# Altium schematic sheet servo-connector.SchDoc(servo-connector)
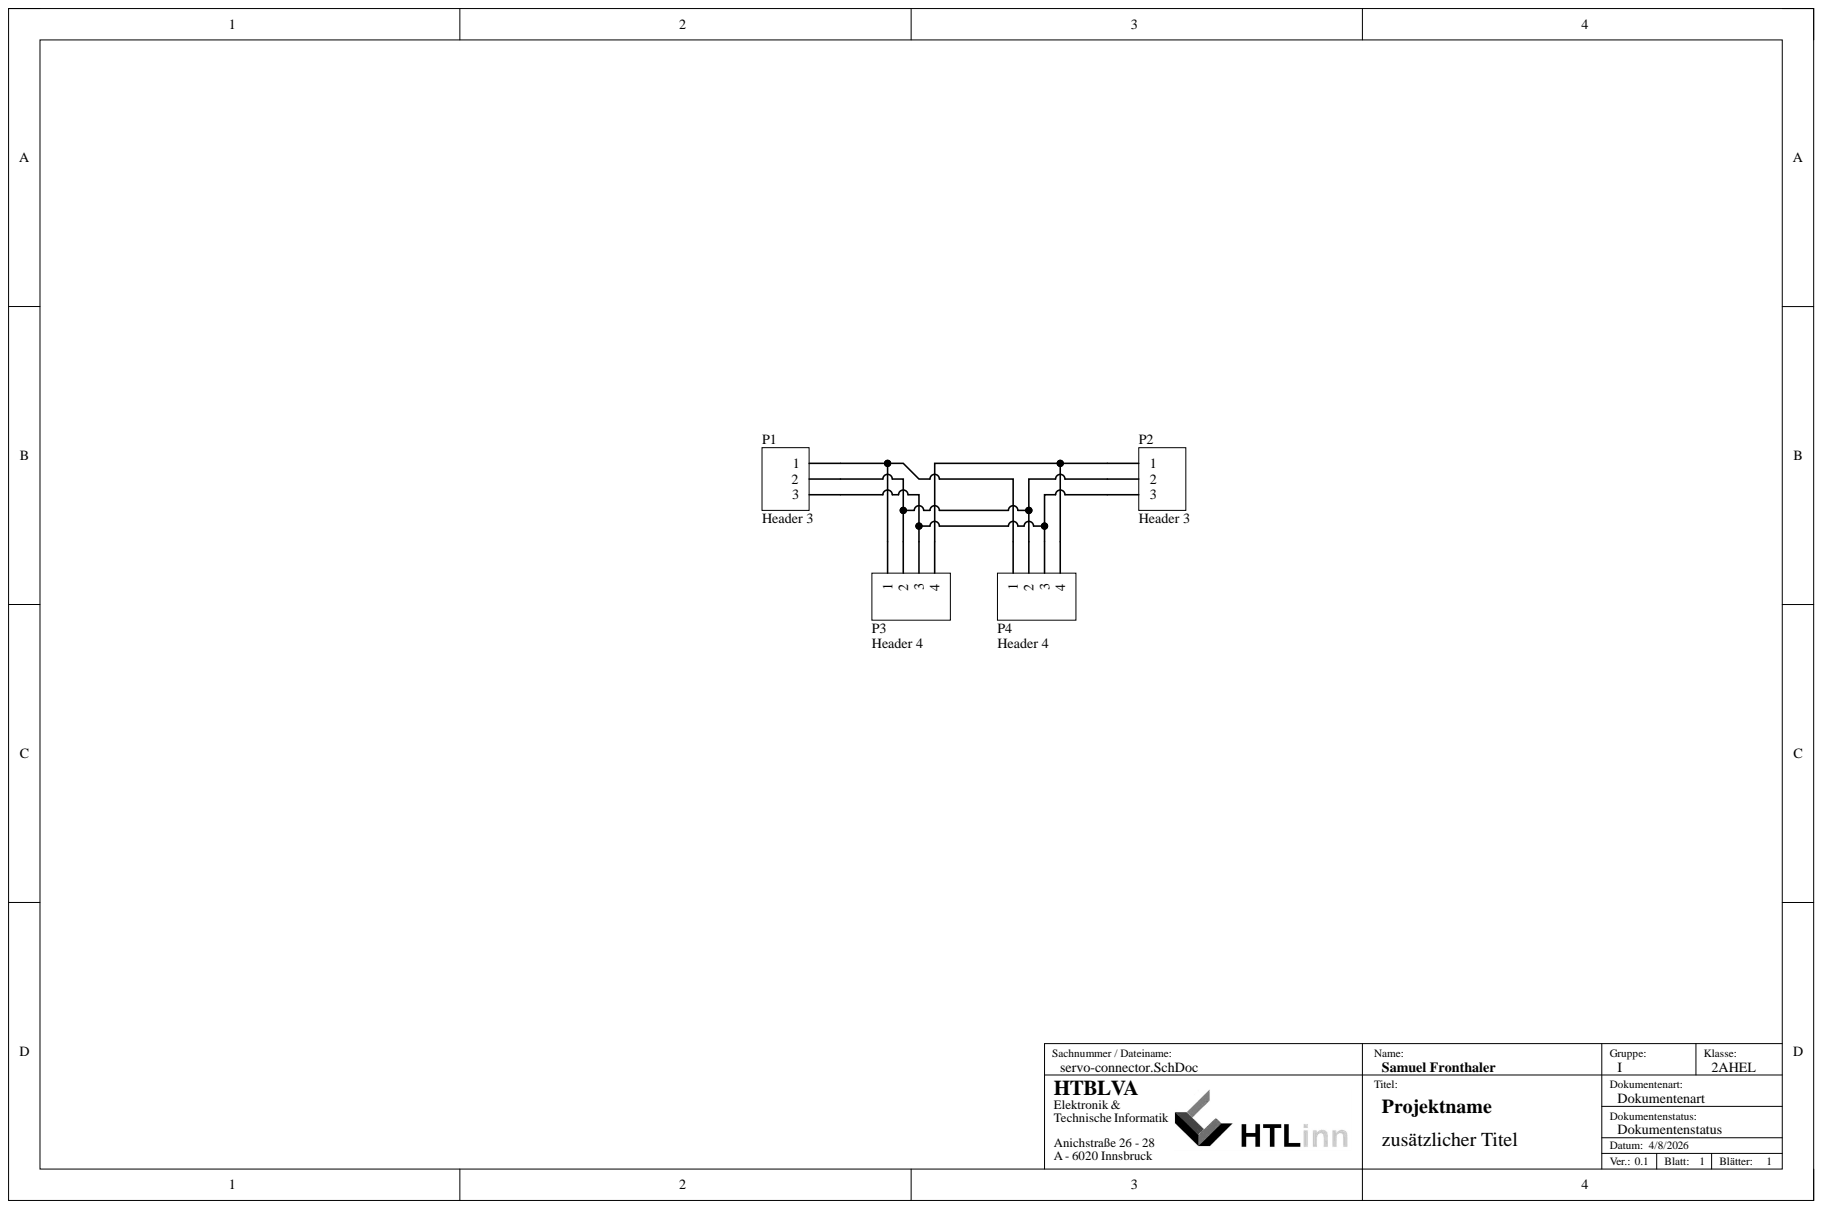
[diagram p0: servo-connector.SchDoc]

## Parts
P1  Header 3  [HDR1X3]
P2  Header 3  [HDR1X3]
P3  Header 4  [HDR1X4]
P4  Header 4  [HDR1X4]

## Nets
NetP1_1: P1.1 P3.1 P4.1
NetP1_2: P1.2 P2.2 P3.2 P4.2
NetP1_3: P1.3 P2.3 P3.3 P4.3
NetP2_1: P2.1 P3.4 P4.4

## Library data of the parts
P1 P2 = Header 3: Header, 3-Pin; Component Kind: Standard; LatestRevisionDate: 17-Jul-2002; LatestRevisionNote: Re-released for DXP Platform.; Library Name: Miscellaneous Connectors.IntLib; Signal Integrity: Connector; Publisher: Altium Limited
P3 P4 = Header 4: Header, 4-Pin; Component Kind: Standard; LatestRevisionDate: 17-Jul-2002; LatestRevisionNote: Re-released for DXP Platform.; Library Name: Miscellaneous Connectors.IntLib; Signal Integrity: Connector; Publisher: Altium Limited
